# Altium schematic sheet Peltie.SchDoc(Peltie)
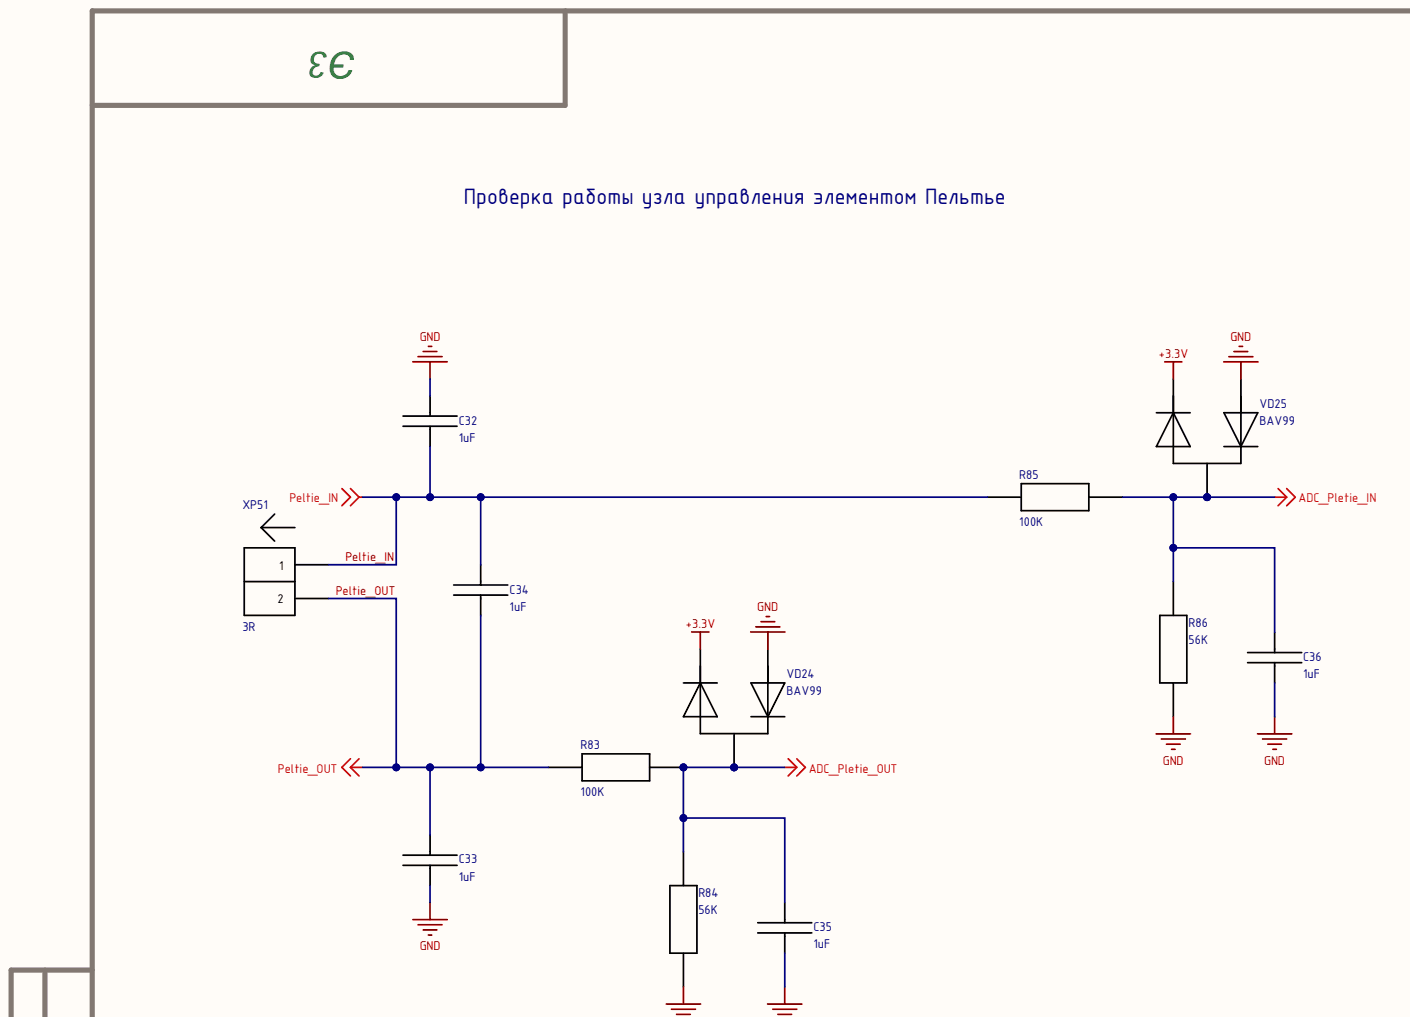
[diagram p0: Peltie.SchDoc, top left]
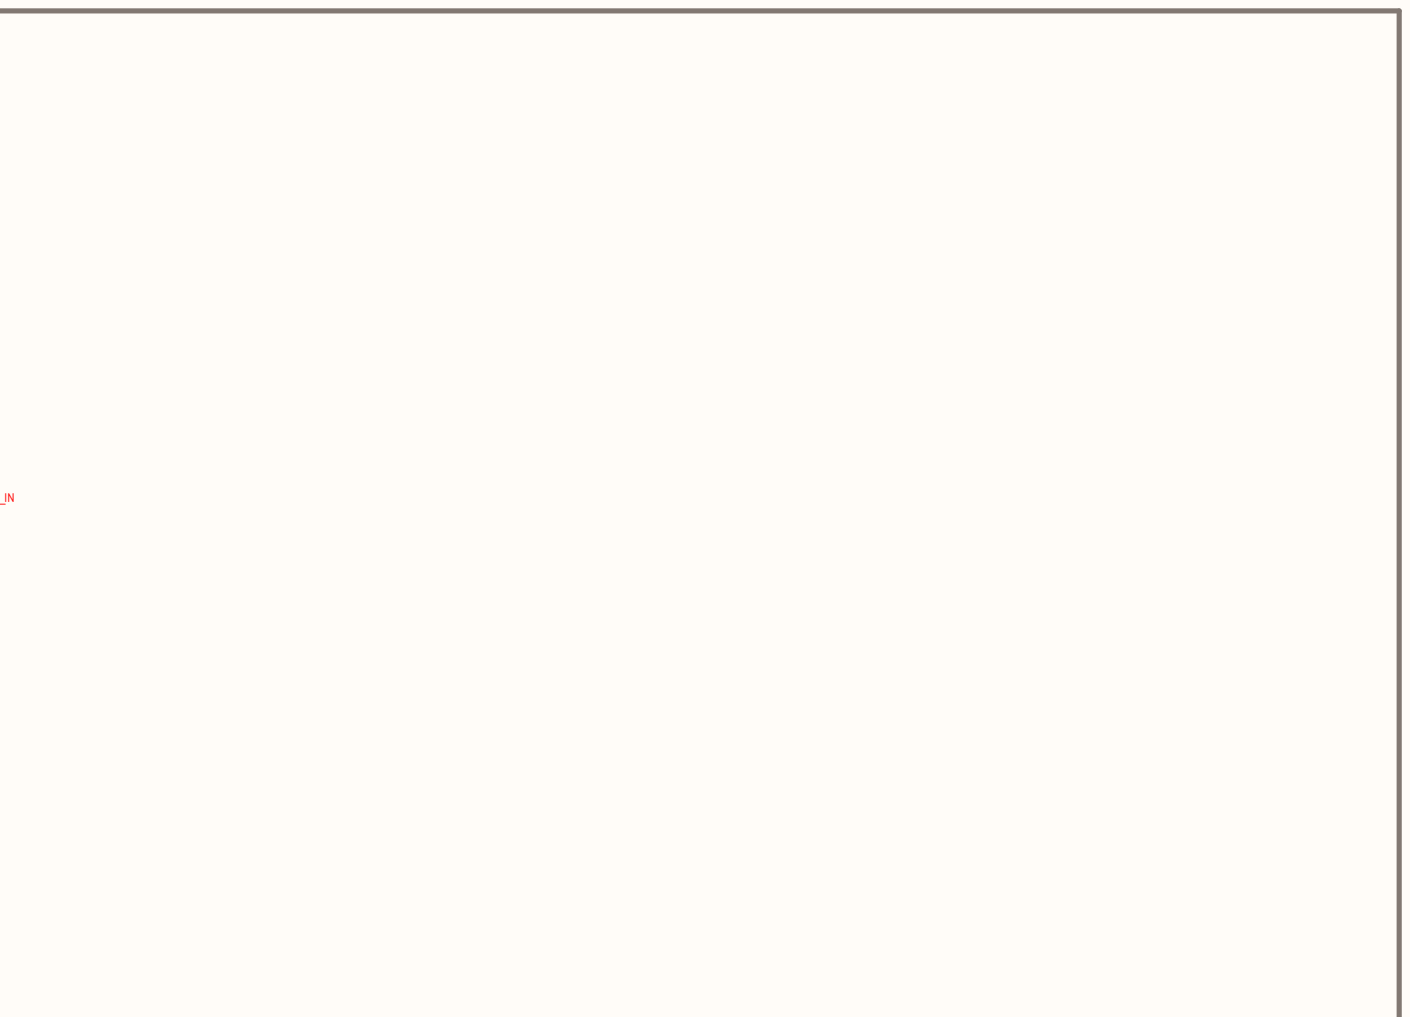
[diagram p1: Peltie.SchDoc, top right]
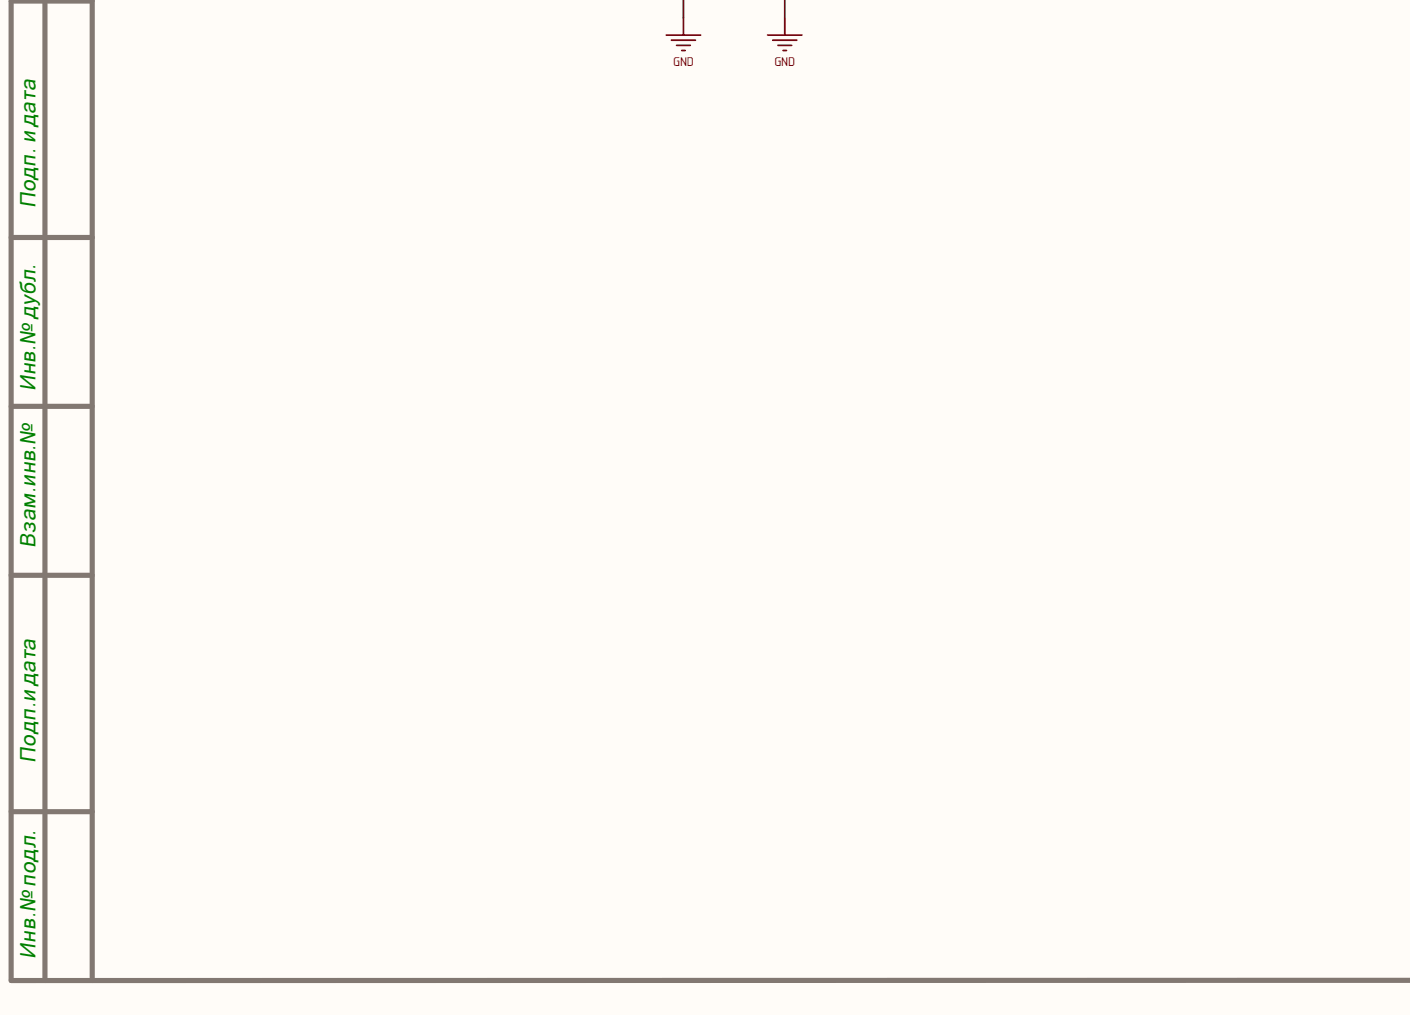
[diagram p2: Peltie.SchDoc, bottom left]
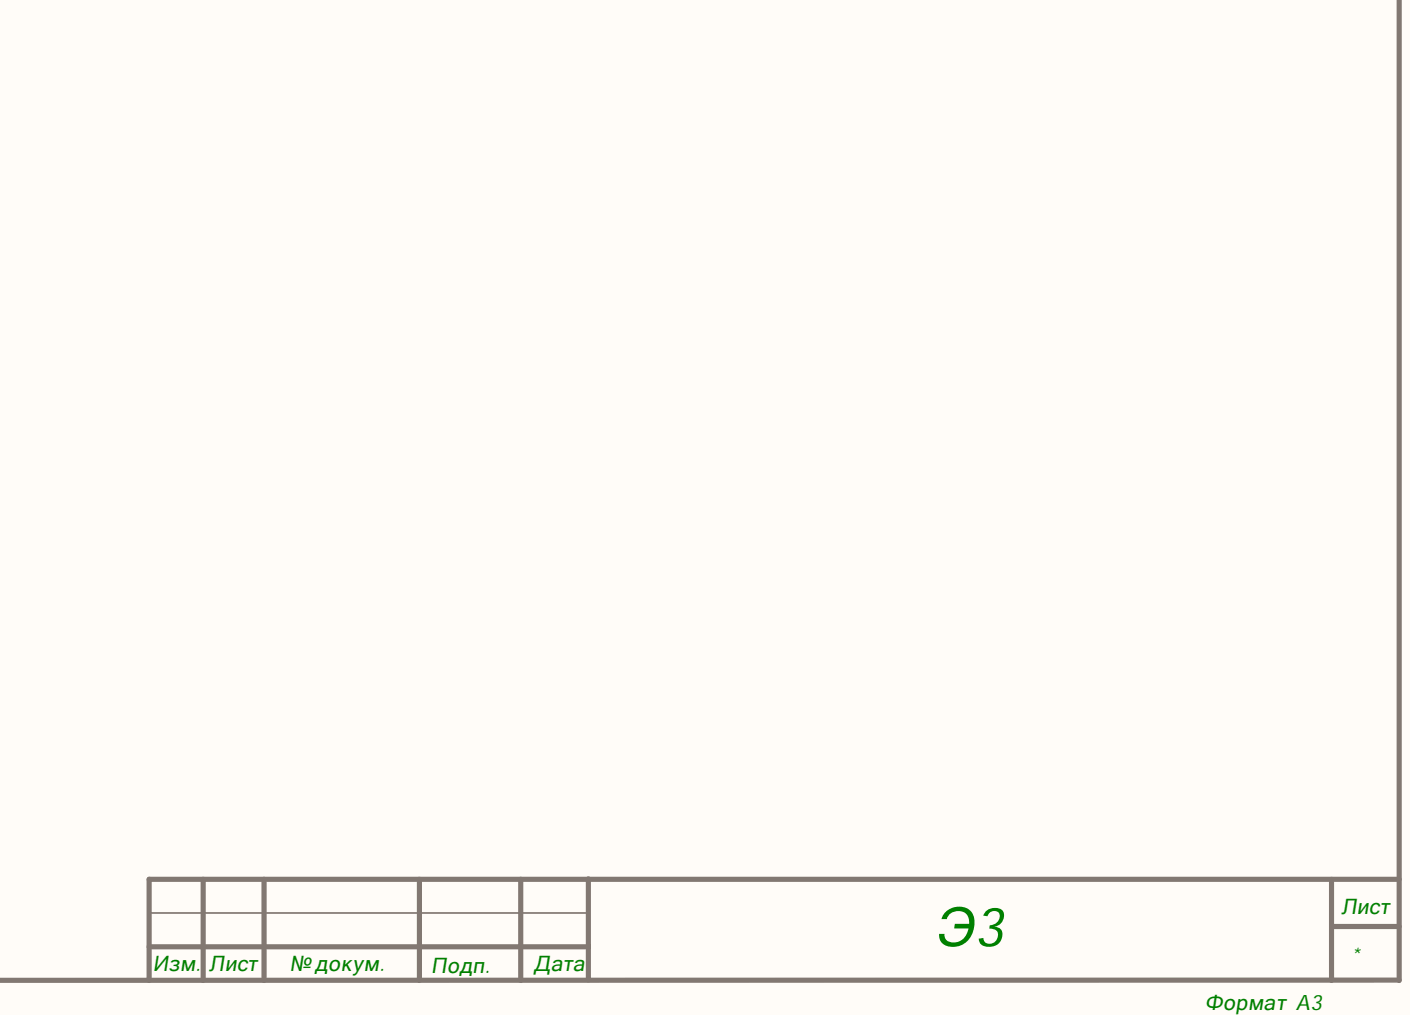
[diagram p3: Peltie.SchDoc, bottom right]

## Parts
C32  1uF  [0603C]
C33  1uF  [0603C]
C34  1uF  [0603C]
C35  1uF  [0603C]
C36  1uF  [0603C]
R83  100K  [R0402]
R84  56K  [R0402]
R85  100K  [R0402]
R86  56K  [R0402]
VD24  BAV99  [SOT-23-3]
VD25  BAV99  [SOT-23-3]
XP51  3R  [PLS-2]

## Nets
+3.3V: VD24.2 VD25.2
ADC_Pletie_IN: C36.2 R85.1 R86.1 VD25.3
ADC_Pletie_OUT: C35.2 R83.1 R84.1 VD24.3
GND: C32.2 C33.2 C35.1 C36.1 R84.2 R86.2 VD24.1 VD25.1
Peltie_IN: C32.1 C34.2 R85.2 XP51.1
Peltie_OUT: C33.1 C34.1 R83.2 XP51.2

## Library data of the parts
C32-C36 = C0603-1uF 10% 50V: Part Number: SMD CAP CER 1uF ±10% 50V X7R 0603; Added_by: grebelny_av@teslatec.ru; Pkg: 0603; Solder_Amount: 2; Component Kind: Standard; Created_at: 22/06/2021; Description: C0603-1uF 10% 50V; Mount: MOUNT; MountType: SMD; Library Name: Test_Stand_Pergam.SchLib
R83 R85 = R0402-100K: Resistor SMD 100K 1% 0402; Part Number: SMD RES 100 KOHM 1% ±100ppm/°C 0402; Added_by: Rutel@teslatec.ru; Pkg: 0402; Solder_Amount: 2; Component Kind: Standard; Created_at: 21/04/2020; Mount: MOUNT; MountType: SMD; Library Name: Test_Stand_Pergam.SchLib
R84 R86 = R0402-56K: Resistor SMD 56K 1% 0402; Part Number: SMD RES 56K KOHM 1% ±100ppm/°C 0402; Added_by: ridel_av@teslatec.ru; Pkg: 0402; Solder_Amount: 2; Component Kind: Standard; Created_at: 15/12/2021; Mount: MOUNT; MountType: SMD; Library Name: Test_Stand_Pergam.SchLib
VD24 VD25 = BAV99: BAV99, UMW, 70В, 200мА; Added_by: andrew@teslatec.ru; Solder_Amount: 3; Component Kind: Standard; Created_at: 29/06/2020; Mount: MOUNT; MountType: SMD; Part Number: BAV99; Pkg: SOT-23-3; Library Name: Test_Stand_Pergam.SchLib
XP51 = PLS-2_peltie: SQP10AJB-3R; Added_by: irdolgopolowa@yandex.ru; Solder_Amount: 2; Component Kind: Standard; Created_at: 29/10/24; Mating Part: SQP10AJB-3R; Mount: MOUNT; MountType: THM; Part Number: PLS-2; Pkg: PLS-2; Library Name: Test_Stand_Pergam.SchLib
